# Altium schematic sheet rtc.SchDoc
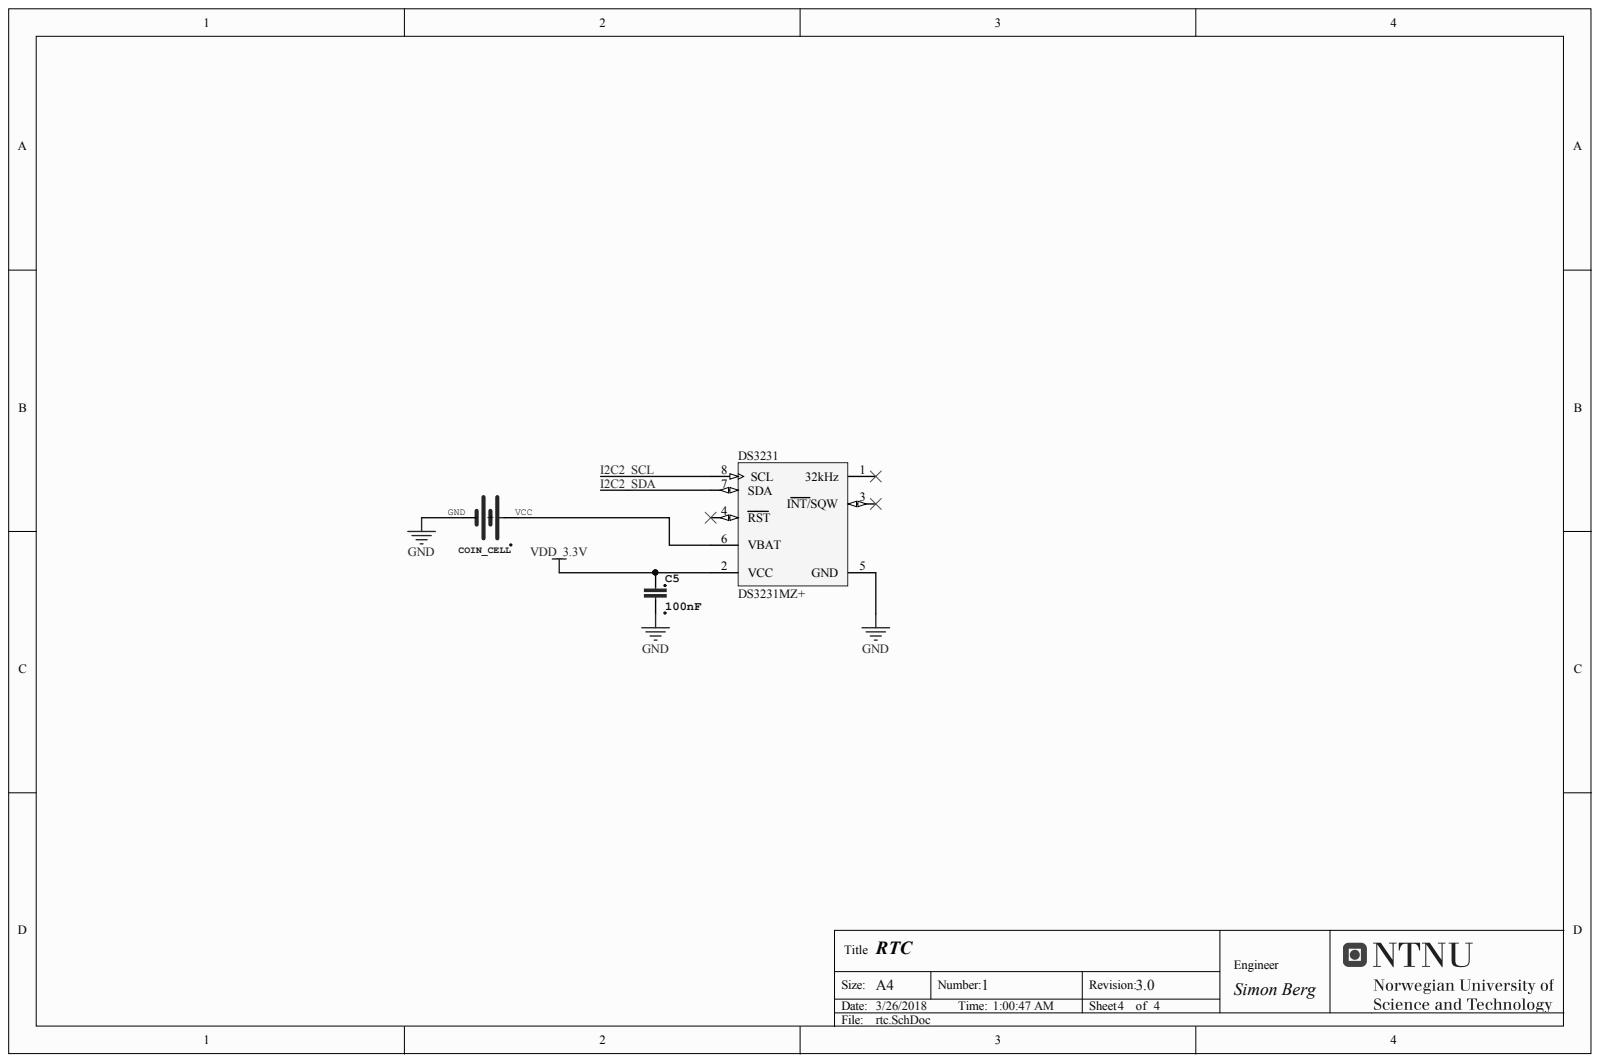
[diagram p0: rtc.SchDoc]

## Parts
C5  100nF  [0603-CAP]
COIN_CELL  Battery Holders Various common sizes : AA, AAA, 20mm coin cell and 12mm coin cell. 20MM_4LEGS, BATT-10373  [CARGPSPA6H_BATTCON_12MM]  (value not drawn)
DS3231  DS3231MZ+  [S8MK+1_L]

## Nets
GND: C5.P$2 COIN_CELL.GND DS3231.5(GND)
VDD_3.3V: C5.P$1 DS3231.2(VCC)
I2C2_SCL: DS3231.8(SCL)
I2C2_SDA: DS3231.7(SDA)
NetCOIN_CELL_VCC: COIN_CELL.VCC DS3231.6(VBAT)

Not connected: DS3231 1(32kHz) 3(INT/SQW) 4(RST)

## Library data of the parts
C5 = CAP0603: Component Kind: Standard; Supplier 1: Digi-Key; Supplier Part Number 1: 490-7611-1-ND; Library Name: cb_untouched.SCHLIB
COIN_CELL = BATTERY_HOLDER12MM: GateName_1: G$1; Component Kind: Standard; DeviceName: 12MM; DeviceSetName: BATTERY_HOLDER; SymbolName_1: BATTERY_HOLDER; Library Name: autowing_connector.SCHLIB; LibraryName: autowing_connector
DS3231 = CMP-0254-00001-2: +/-5ppm, MEMS based Real-Time Clock, I2C Interface, 8-Pin SO, -40 to 85 degC, Pb-Free; Code_IPC: SOIC127P600-8; Code_JEDEC: MS-012-AA; Component Kind: Standard; ComponentLink1Description: Manufacturer URL; ComponentLink1URL: http://www.maxim-ic.com; ComponentLink2Description: Datasheet; ComponentLink2URL: http://datasheets.maxim-ic.com/en/ds/DS3231M.pdf; ComponentLink3Description: Package Specification; ComponentLink3URL: http://pdfserv.maxim-ic.com/package_dwgs/21-0041.PDF; DatasheetVersion: Rev 3, Dec-2011; Library Name: Altium Content Vault; PackageDescription: 8-Pin Small-Outline IC, Narrow (0.15in), 1.27 mm Pitch, SOIC (N), Pb-Free; PackageReference: S8MK+1; PackageVersion: revC, 2010
